# Altium schematic sheet ZeroCrossDetector.SchDoc
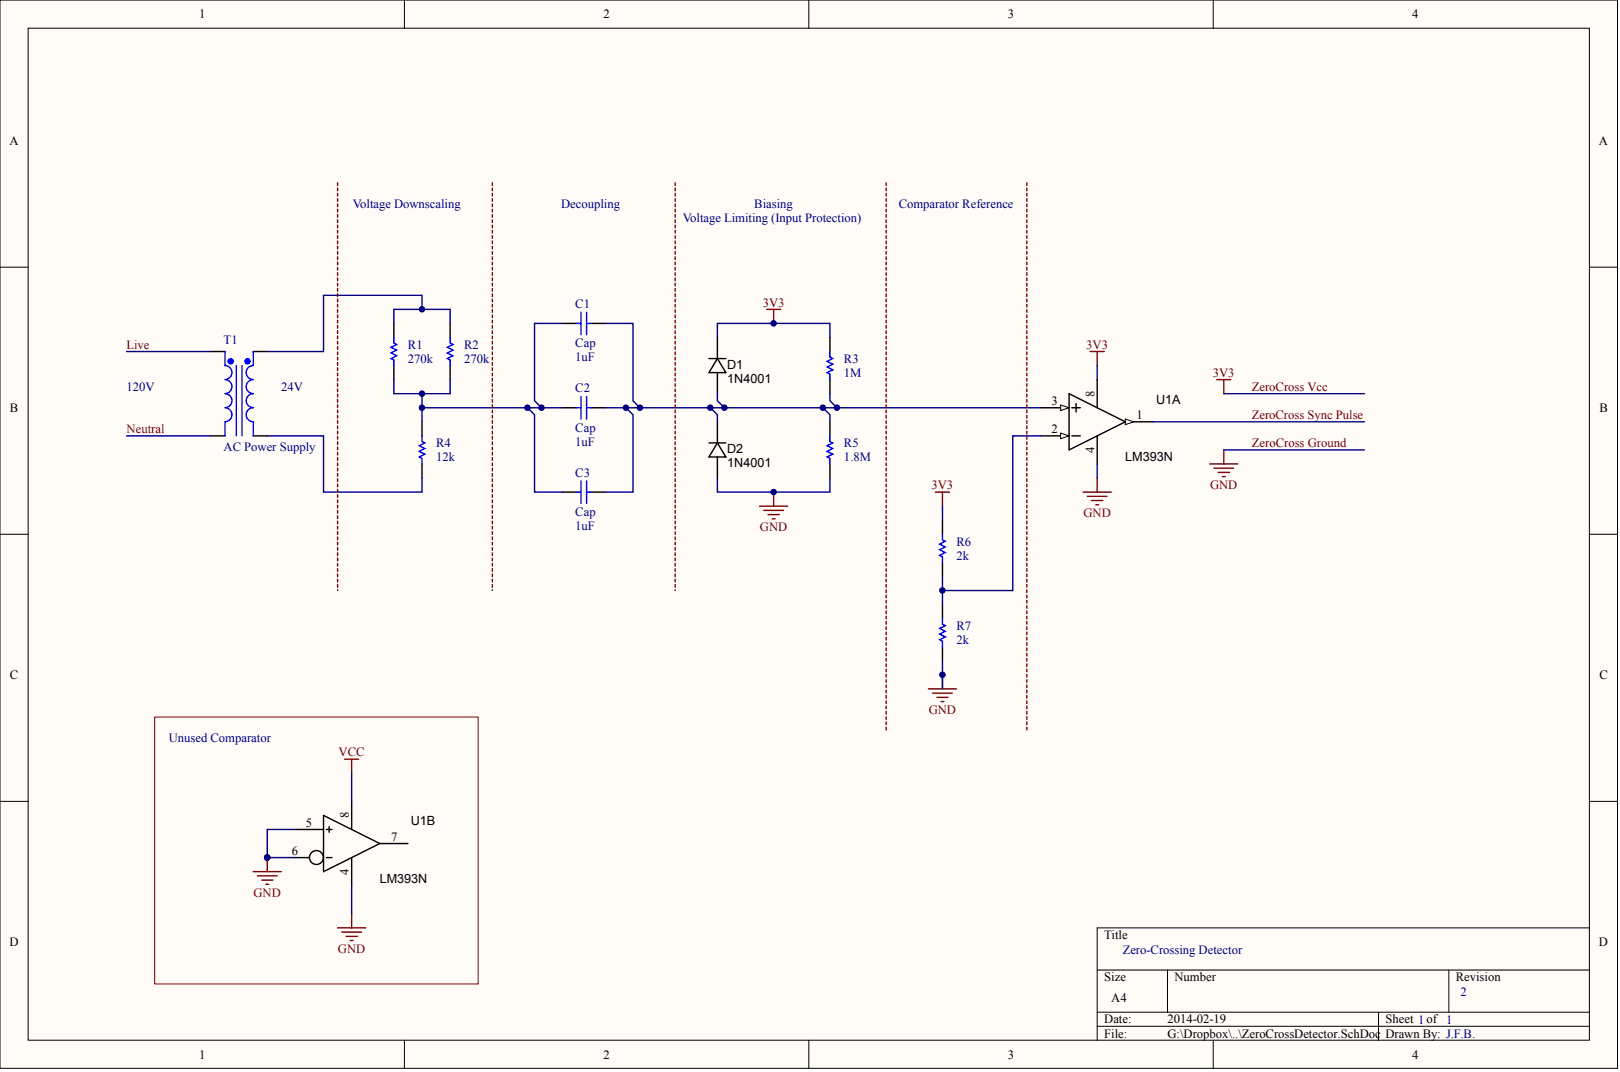
[diagram p0: ZeroCrossDetector.SchDoc]

## Parts
C1  Cap  value 1uF
C2  Cap  value 1uF
C3  Cap  value 1uF
D1  1N4001
D2  1N4001
R1  value 270k
R2  value 270k
R3  value 1M
R4  value 12k
R5  value 1.8M
R6  value 2k
R7  value 2k
T1  AC Power Supply
U1  LM393N  (parts A,B)

## Nets
VCC: U1.8
LIVE: T1.1
NEUTRAL: T1.2
ZEROCROSS GROUND: D2.A R5.1 R7.1 U1.4 U1.5 U1.6
ZEROCROSS SYNC PULSE: U1.1
ZEROCROSS VCC: D1.K R3.2 R6.2 U1.8
NetC1_1: C1.1 C2.1 C3.1 R1.1 R2.1 R4.2
NetC1_2: C1.2 C2.2 C3.2 D1.A D2.K R3.1 R5.2 U1.3
NetR1_2: R1.2 R2.2 T1.3
NetR4_1: R4.1 T1.4
NetR6_1: R6.1 R7.2 U1.2

Not connected: U1 7

## Library data of the parts
D1 D2 = (no symbol name): Manufacturer 1: Diodes Inc; Manufacturer Part Number 1: 1N4007-T; Ratings: 100V; 1.5A; Diode Type: Standard; Value: Vf = 1.15V @ 1.5A (Max); SCDType: spec; Package / Case: DO-41; Mounting Type: Through Hole
U1 = (no symbol name): Manufacturer 1: Fairchild Semiconductor; Voltage - Supply: 2 V ~ 36 V, ±1 V ~ 18 V; SCDType: undef; Manufacturer Part Number 1: LM393N; Package / Case: 8-DIP; Supplier 1: Digi-Key; Supplier Part Number 1: LM393NFS-ND
C1-C3 R1-R7 T1 = (no symbol name): Published: 8-Jun-2000; LatestRevisionDate: 17-Jul-2002; LatestRevisionNote: Re-released for DXP Platform.; Publisher: Altium Limited
  C1-C3: PackageReference: RAD-0.3; PackageDescription: Radial Cap, Thru-Hole; 2 Leads; 0.3 in Pin Spacing
  R1-R7: PackageReference: AXIAL-0.3; PackageDescription: Axial Device, Thru-Hole; 2 Leads; 0.3 in Pin Spacing
  T1: PackageReference: TRF_4; PackageDescription: Transformer; 4 Leads
